# Altium schematic sheet Microcontroller.SchDoc(Microcontroller)
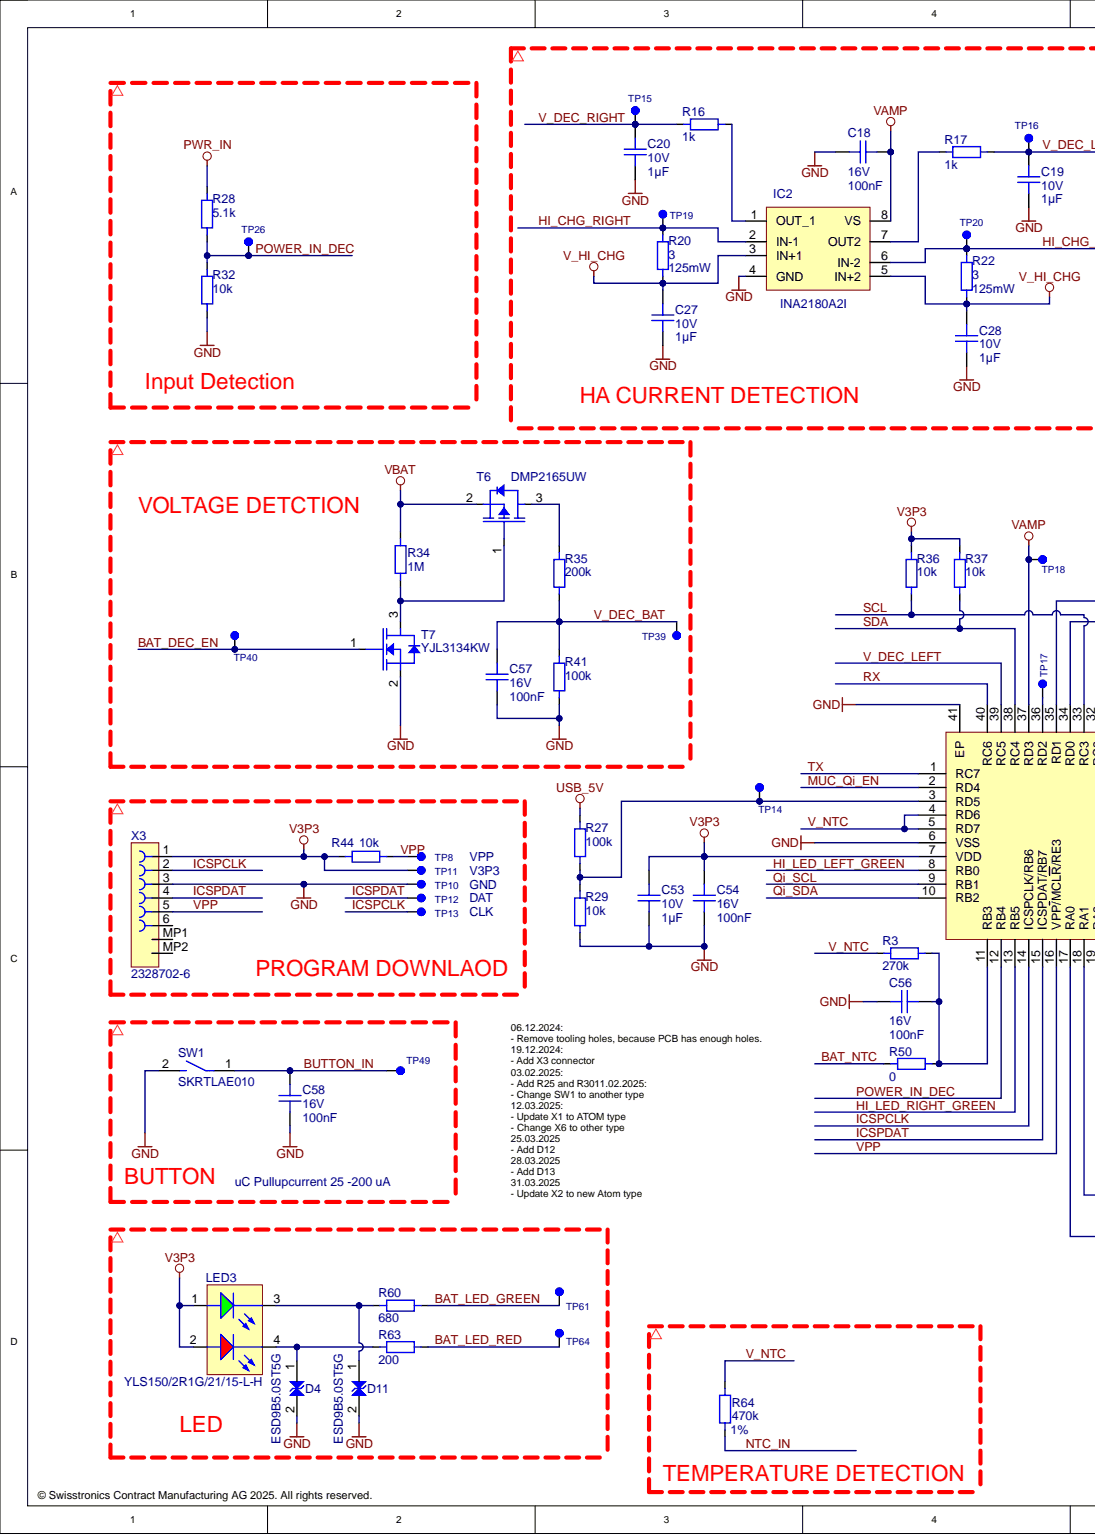
[diagram p0: Microcontroller.SchDoc, left]
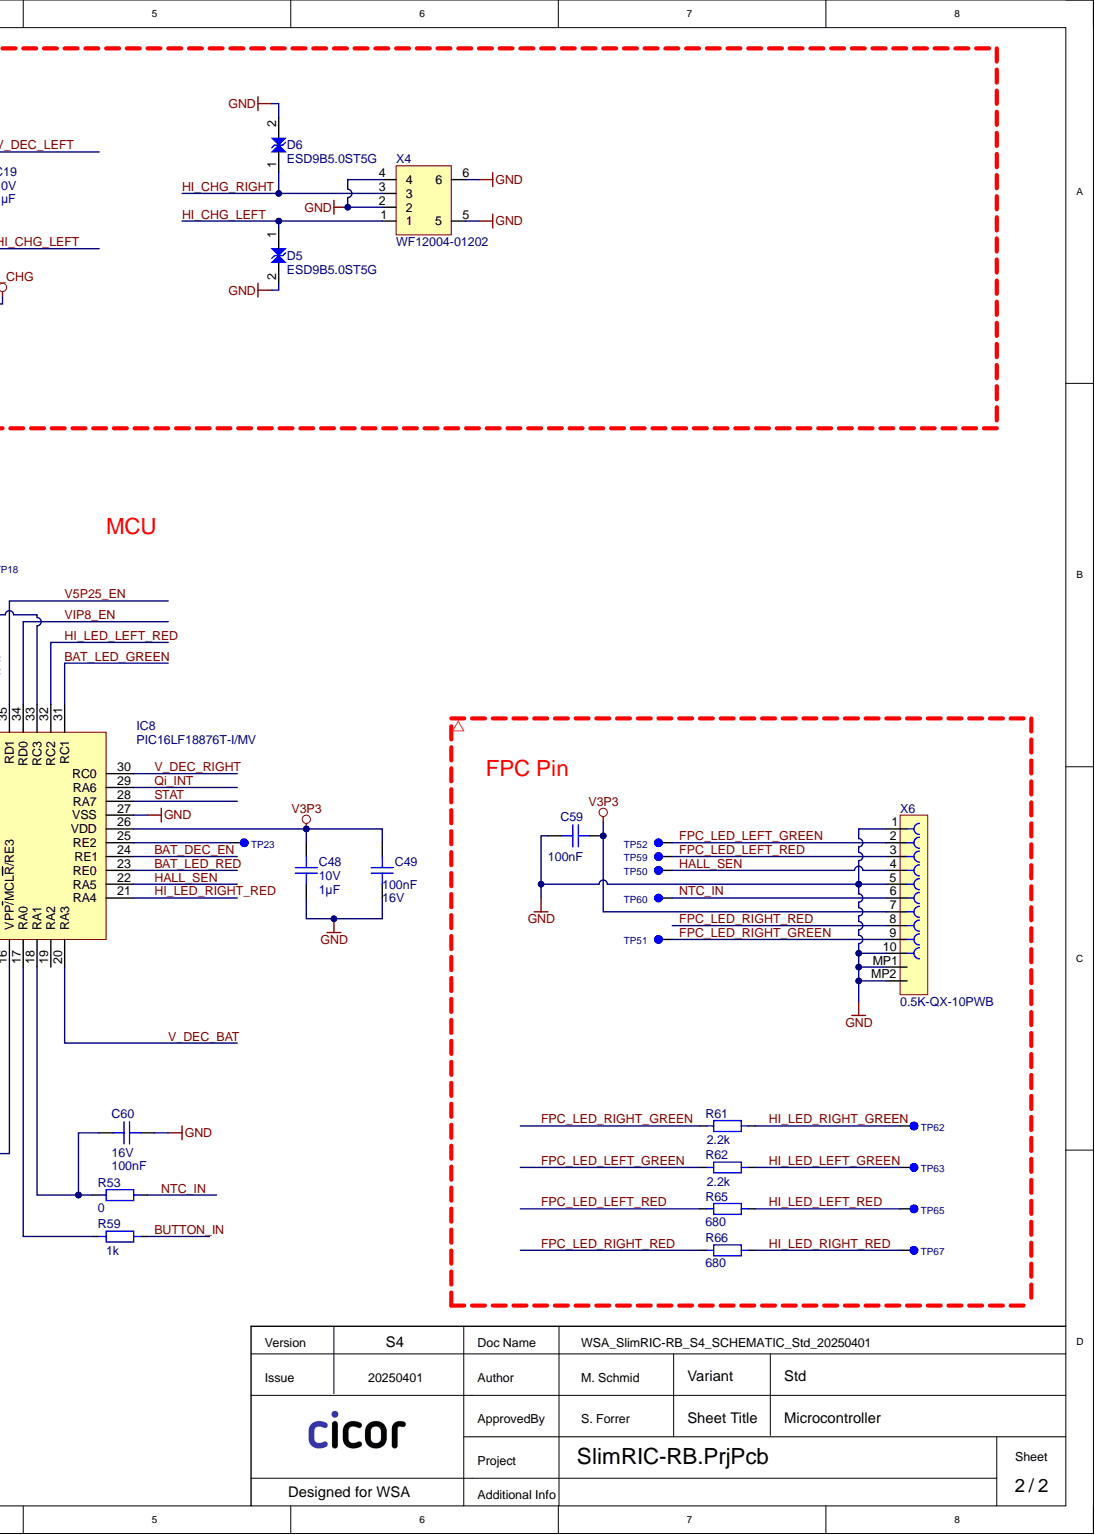
[diagram p1: Microcontroller.SchDoc, right]

## Parts
C18  value 100nF  VoltageRated: 16V  [CAPC0603X02N-0201IPC]  (hidden comment: C_100nF_16V_10%_X5R_0201)
C19  value 1µF  VoltageRated: 10V  [CAPC0603X02N-0201IPC]  (hidden comment: C_1uF_10V_20%_X5R_0201)
C20  value 1µF  VoltageRated: 10V  [CAPC0603X02N-0201IPC]  (hidden comment: C_1uF_10V_20%_X5R_0201)
C27  value 1µF  VoltageRated: 10V  [CAPC0603X02N-0201IPC]  (hidden comment: C_1uF_10V_20%_X5R_0201)
C28  value 1µF  VoltageRated: 10V  [CAPC0603X02N-0201IPC]  (hidden comment: C_1uF_10V_20%_X5R_0201)
C48  value 1µF  VoltageRated: 10V  [CAPC0603X02N-0201IPC]  (hidden comment: C_1uF_10V_20%_X5R_0201)
C49  value 100nF  VoltageRated: 16V  [CAPC0603X02N-0201IPC]  (hidden comment: C_100nF_16V_10%_X5R_0201)
C53  value 1µF  VoltageRated: 10V  [CAPC0603X02N-0201IPC]  (hidden comment: C_1uF_10V_20%_X5R_0201)
C54  value 100nF  VoltageRated: 16V  [CAPC0603X02N-0201IPC]  (hidden comment: C_100nF_16V_10%_X5R_0201)
C56  value 100nF  VoltageRated: 16V  [CAPC0603X02N-0201IPC]  (hidden comment: C_100nF_16V_10%_X5R_0201)
C57  value 100nF  VoltageRated: 16V  [CAPC0603X02N-0201IPC]  (hidden comment: C_100nF_16V_10%_X5R_0201)
C58  value 100nF  VoltageRated: 16V  [CAPC0603X02N-0201IPC]  (hidden comment: C_100nF_16V_10%_X5R_0201)
C59  value 100nF  [CAPC0603X02N-0201IPC]  (hidden comment: C_100nF_16V_10%_X5R_0201)
C60  value 100nF  VoltageRated: 16V  [CAPC0603X02N-0201IPC]  (hidden comment: C_100nF_16V_10%_X5R_0201)
D4  value ESD9B5.0ST5G  [SOD-923]  (hidden comment: Onsemi_ESD9B5.0)
D5  value ESD9B5.0ST5G  Manufacturer3PN: ESD9B5.0ST5G  [SOD-923]  (hidden comment: Onsemi_ESD9B5.0)
D6  value ESD9B5.0ST5G  Manufacturer3PN: ESD9B5.0ST5G  [SOD-923]  (hidden comment: Onsemi_ESD9B5.0)
D11  value ESD9B5.0ST5G  Manufacturer3PN: ESD9B5.0ST5G  [SOD-923]  (hidden comment: Onsemi_ESD9B5.0)
IC2  value INA2180A2I  [VSSOP-8]  (hidden comment: TI_INA2180A2IDGKR)
IC8  value PIC16LF18876T-I/MV  [QFN40P500X500X90_HS-41L]  (hidden comment: Microchip_PIC16LF18876T-I/MV)
LED3  value YLS150/2R1G/21/15-L-H  Manufacturer1PN: YLS150/2R1G/21/15-L-H  [Y.LIN YLS150/2R1G/21/15-L-H]  (hidden comment: YLIN_YLS150/2R1G/21/15-L-H)
R3  value 270k  [RESC0603X02N-0201IPC]  (hidden comment: R_270k_50mW_200ppm_1%_0201)
R16  value 1k  [RESC0603X02N-0201IPC]  (hidden comment: R_1k_50mW_200ppm_1%_0201)
R17  value 1k  [RESC0603X02N-0201IPC]  (hidden comment: R_1k_50mW_200ppm_1%_0201)
R20  value 3  PowerDissipation: 125mW  [RESC2012X06L]  (hidden comment: R_3R_125mW_200ppm_1%_0805)
R22  value 3  PowerDissipation: 125mW  [RESC2012X06L]  (hidden comment: R_3R_125mW_200ppm_1%_0805)
R27  value 100k  [RESC0603X02N-0201IPC]  (hidden comment: R_100k_50mW_200ppm_1%_0201)
R28  value 5.1k  [RESC0603X02N-0201IPC]  (hidden comment: R_5.1k_50mW_200ppm_1%_0201)
R29  value 10k  [RESC0603X02N-0201IPC]  (hidden comment: R_10k_50mW_200ppm_1%_0201)
R32  value 10k  [RESC0603X02N-0201IPC]  (hidden comment: R_10k_50mW_200ppm_1%_0201)
R34  value 1M  [RESC0603X02N-0201IPC]  (hidden comment: R_1M_50mW_200ppm_1%_0201)
R35  value 200k  [RESC0603X02N-0201IPC]  (hidden comment: R_200k_50mW_200ppm_1%_0201)
R36  value 10k  [RESC0603X02N-0201IPC]  (hidden comment: R_10k_50mW_200ppm_1%_0201)
R37  value 10k  [RESC0603X02N-0201IPC]  (hidden comment: R_10k_50mW_200ppm_1%_0201)
R41  value 100k  [RESC0603X02N-0201IPC]  (hidden comment: R_100k_50mW_200ppm_1%_0201)
R44  value 10k  [RESC0603X02N-0201IPC]  (hidden comment: R_10k_50mW_200ppm_1%_0201)
R50  value 0  [RESC0603X02N-0201IPC]  (hidden comment: R_0R_0.5A_0201)
R53  value 0  [RESC0603X02N-0201IPC]  (hidden comment: R_0R_0.5A_0201)
R59  value 1k  [RESC0603X02N-0201IPC]  (hidden comment: R_1k_50mW_200ppm_1%_0201)
R60  value 680  [RESC0603X02N-0201IPC]  (hidden comment: R_680R_50mW_200ppm_1%_0201)
R61  value 2.2k  [RESC0603X02N-0201IPC]  (hidden comment: R_2.2k_50mW_200ppm_1%_0201)
R62  value 2.2k  [RESC0603X02N-0201IPC]  (hidden comment: R_2.2k_50mW_200ppm_1%_0201)
R63  value 200  [RESC0603X02N-0201IPC]  (hidden comment: R_200R_50mW_200ppm_1%_0201)
R64  value 470k  Tolerance: 1%  [RESC0603X02N-0201IPC]  (hidden comment: R_470k_50mW_200ppm_1%_0201)
R65  value 680  [RESC0603X02N-0201IPC]  (hidden comment: R_680R_50mW_200ppm_1%_0201)
R66  value 680  [RESC0603X02N-0201IPC]  (hidden comment: R_680R_50mW_200ppm_1%_0201)
SW1  value SKRTLAE010  Manufacturer1PN: SKRTLAE010  [Alps_SKRKAGE010]  (hidden comment: ALPS_SKRTLAE010)
T6  value DMP2165UW  [SOT65P210X110-3N]  (hidden comment: Diodes_DMP2165UW-7)
T7  value YJL3134KW  [SOT-323-Yangjie]  (hidden comment: Yangjie_YJL3134KW)
TP8  TestPoint  [TP_080mm]  (value not drawn)  (no BOM)
TP10  TestPoint  [TP_080mm]  (value not drawn)  (no BOM)
TP11  TestPoint  [TP_080mm]  (value not drawn)  (no BOM)
TP12  TestPoint  [TP_080mm]  (value not drawn)  (no BOM)
TP13  TestPoint  [TP_080mm]  (value not drawn)  (no BOM)
TP14  TestPoint  [TP_080mm]  (value not drawn)  (no BOM)
TP15  TestPoint  [TP_080mm]  (value not drawn)  (no BOM)
TP16  TestPoint  [TP_080mm]  (value not drawn)  (no BOM)
TP17  TestPoint  [TP_080mm]  (value not drawn)  (no BOM)
TP18  TestPoint  [TP_080mm]  (value not drawn)  (no BOM)
TP19  TestPoint  [TP_080mm]  (value not drawn)  (no BOM)
TP20  TestPoint  [TP_080mm]  (value not drawn)  (no BOM)
TP23  TestPoint  [TP_080mm]  (value not drawn)  (no BOM)
TP26  TestPoint  [TP_080mm]  (value not drawn)  (no BOM)
TP39  TestPoint  [TP_080mm]  (value not drawn)  (no BOM)
TP40  TestPoint  [TP_080mm]  (value not drawn)  (no BOM)
TP49  TestPoint  [TP_080mm]  (value not drawn)  (no BOM)
TP50  TestPoint  [TP_080mm]  (value not drawn)  (no BOM)
TP51  TestPoint  [TP_080mm]  (value not drawn)  (no BOM)
TP52  TestPoint  [TP_080mm]  (value not drawn)  (no BOM)
TP59  TestPoint  [TP_080mm]  (value not drawn)  (no BOM)
TP60  TestPoint  [TP_080mm]  (value not drawn)  (no BOM)
TP61  TestPoint  [TP_080mm]  (value not drawn)  (no BOM)
TP62  TestPoint  [TP_080mm]  (value not drawn)  (no BOM)
TP63  TestPoint  [TP_080mm]  (value not drawn)  (no BOM)
TP64  TestPoint  [TP_080mm]  (value not drawn)  (no BOM)
TP65  TestPoint  [TP_080mm]  (value not drawn)  (no BOM)
TP67  TestPoint  [TP_080mm]  (value not drawn)  (no BOM)
X3  value 2328702-6  Manufacturer1PN: 2328702-6  [TE_2328702-6]  (hidden comment: TE_2328702-6)
X4  value WF12004-01202  Manufacturer1PN: WF12004-01202  [Atom_WF12004-012XX-4Pin]  (hidden comment: Atom_WF12004-01202-4Pin)
X6  value 0.5K-QX-10PWB  Manufacturer1PN: 0.5K-QX-10PWB  [HDGC_0.5K-QX-10PWB]  (hidden comment: HDGC_0.5K-QX-10PWB)

## Nets
GND: C18.1 C19.1 C20.1 C27.1 C28.1 C48.1 C49.1 C53.1 C54.1 C56.1 C57.2 C58.1 C59.2 C60.1 D4.2 D5.2 D6.2 D11.2 IC2.4(GND) IC8.6(VSS) IC8.27(VSS) IC8.41(EP) R29.1 R32.1 R41.1 SW1.2 T7.2 TP10.1 X3.3 X4.2 X4.4 X4.5 X4.6 X6.1 X6.5 X6.10 X6.MP1 X6.MP2
PWR_IN: R28.1
USB_5V: R27.2
V3P3: C48.2 C49.2 C53.2 C54.2 C59.1 IC8.7(VDD) IC8.26(VDD) LED3.1 LED3.2 R36.2 R37.2 R44.1 TP11.1 X3.1 X6.7
V_HI_CHG: C27.2 C28.2 IC2.3(IN+1) IC2.5(IN+2) R20.1 R22.1
VAMP: C18.2 IC2.8(VS) IC8.37(RD3) TP18.1
VBAT: R34.2 T6.2
BAT_DEC_EN: IC8.24(RE1) T7.1 TP40.1
BAT_LED_GREEN: IC8.31(RC1) R60.2 TP61.1
BAT_LED_RED: IC8.23(RE0) R63.2 TP64.1
BAT_NTC: R50.1
BUTTON_IN: C58.2 R59.2 SW1.1 TP49.1
FPC_LED_LEFT_GREEN: R62.1 TP52.1 X6.2
FPC_LED_LEFT_RED: R65.1 TP59.1 X6.3
FPC_LED_RIGHT_GREEN: R61.1 TP51.1 X6.9
FPC_LED_RIGHT_RED: R66.1 X6.8
HALL_SEN: IC8.22(RA5) TP50.1 X6.4
HI_CHG_LEFT: D5.1 IC2.6(IN-2) R22.2 TP20.1 X4.1
HI_CHG_RIGHT: D6.1 IC2.2(IN-1) R20.2 TP19.1 X4.3
HI_LED_LEFT_GREEN: IC8.8(RB0) R62.2 TP63.1
HI_LED_LEFT_RED: IC8.32(RC2) R65.2 TP65.1
HI_LED_RIGHT_GREEN: IC8.13(RB5) R61.2 TP62.1
HI_LED_RIGHT_RED: IC8.21(RA4) R66.2 TP67.1
ICSPCLK: IC8.14(ICSPCLK/RB6) TP13.1 X3.2
ICSPDAT: IC8.15(ICSPDAT/RB7) TP12.1 X3.4
MUC_Qi_EN: IC8.2(RD4)
NTC_IN: R53.2 R64.1 TP60.1 X6.6
POWER_IN_DEC: IC8.12(RB4) R28.2 R32.2 TP26.1
Qi_INT: IC8.29(RA6)
Qi_SCL: IC8.9(RB1)
Qi_SDA: IC8.10(RB2)
RX: IC8.40(RC6)
SCL: IC8.33(RC3) R36.1
SDA: IC8.38(RC4) R37.1
STAT: IC8.28(RA7)
TX: IC8.1(RC7)
V5P25_EN: IC8.35(RD1)
V_DEC_BAT: C57.1 IC8.20(RA3) R35.1 R41.2 TP39.1
V_DEC_LEFT: C19.2 IC8.39(RC5) R17.1 TP16.1
V_DEC_RIGHT: C20.2 IC8.30(RC0) R16.1 TP15.1
V_NTC: IC8.4(RD6) IC8.5(RD7) R3.1 R64.2
VIP8_EN: IC8.34(RD0)
VPP: IC8.16(VPP/MCLR/RE3) R44.2 TP8.1 X3.5
NetC56_2: C56.2 IC8.11(RB3) R3.2 R50.2
NetC60_2: C60.2 IC8.18(RA1) R53.1
NetD4_1: D4.1 LED3.4 R63.1
NetD11_1: D11.1 LED3.3 R60.1
NetIC2_1: IC2.1(OUT_1) R16.2
NetIC2_7: IC2.7(OUT2) R17.2
NetIC8_3: IC8.3(RD5) R27.1 R29.2 TP14.1
NetIC8_17: IC8.17(RA0) R59.1
NetIC8_25: IC8.25(RE2) TP23.1
NetIC8_36: IC8.36(RD2) TP17.1
NetR34_1: R34.1 T6.1 T7.3
NetR35_2: R35.2 T6.3

Not connected: IC8 19(RA2); X3 6 MP1 MP2

## Library data of the parts
C18 C49 C54 C56-C60 = CMP-263-000020-11: CAP.CER 100nF 16V X5R 0201; Manufacturer1: Yageo; Manufacturer1PN: CC0201KRX5R7BB104; PartNumber: 4079999; PartNumberCicor: 100nF/16V/X5R/0603(0201)/10%; TempRange: -40°C/+85°C; Tolerance: 10%; Type: Ceramic; Component Kind: Standard; Dielectric: X5R; Housing: 0201; Manufacturer2: Various; Manufacturer2PN: C_100nF_16V_10%_X5R_0201; PartNumberR&D: C_100nF_16V_10%_X5R_0201; Library Name: SWT
  C56 C60: Value: 100nF
  C59: VoltageRated: 16V
C19 C20 C27 C28 C48 C53 = CMP-263-000197-11: CAP.CER 1uF 10V 20% X5R 0201; Manufacturer1: Samsung; Manufacturer1PN: CL03A105MP3ZSNH; Manufacturer2PN: CM03X5R105M10AH; TempRange: -55°C/+85°C; Type: Ceramic; Component Kind: Standard; Dielectric: X5R; Housing: 0201; Manufacturer2: Kyocera; Manufacturer3: Various; Manufacturer3PN: C_1uF_10V_20%_X5R_0201; Supplier1: Digi-Key; Supplier1PN: 1253-CM03X5R105M10AHCT-ND; Tolerance: 20%; Library Name: SWT
D4 = CMP-00108-13: TVS DIODE 5VWM SOD923; Manufacturer1: LRC; Manufacturer1PN: LESD9D5.0CT5G; Manufacturer2PN: ESD9D5C; Manufacturer3PN: ESD9B5.0ST5G; PartNumber: onsemi_ESD9B5.0ST5G; TempRange: -55°C/+150°C; Value: ESD9B5.0ST5G; VoltageBreakdown: 5.8V; VoltageRated: 5V; Availability: SiliconExpert 02.02.2023: 6.6 years; ClassName: LED; Component Kind: Standard; ComponentLink1Description: Datasheet; ComponentLink1URL: \\CTCHFS01.cicor.local\epg$\EDA\Documents\Datasheets\ESD9B-D.PDF; ComponentLink2Description: Datasheet Web; ComponentLink2URL: https://www.onsemi.cn/pdf/datasheet/esd9b-d.pdf; ComponentLink3Description: Datasheet Leiditech; ComponentLink3URL: \\CTCHFS01.cicor.local\epg$\EDA\Documents\Datasheets\ESD9D5C.pdf; ComponentLink4Description: Datasheet Leiditech Web; ComponentLink4URL: https://de.leiditech.com/u_file/file/products/2212/16/86a2a579d2.pdf; ComponentLink5Description: Datasheet LRC; ComponentLink5URL: \\CTCHFS01.cicor.local\epg$\EDA\Documents\Datasheets\LRC_LESD9D5.0CT5G.pdf; Housing: SOD923; Manufacturer2: Leiditech; Manufacturer3: Onsemi; PartNumberCicor: onsemi_ESD9B5.0ST5G; Library Name: SWT
D5 D6 D11 = CMP-00108-13: TVS DIODE 5VWM SOD923; Manufacturer1: LRC; Manufacturer1PN: LESD9D5.0CT5G; Manufacturer2PN: ESD9D5C; PartNumber: onsemi_ESD9B5.0ST5G; TempRange: -55°C/+150°C; VoltageBreakdown: 5.8V; VoltageRated: 5V; Availability: SiliconExpert 02.02.2023: 6.6 years; Component Kind: Standard; ComponentLink1Description: Datasheet; ComponentLink1URL: \\CTCHFS01.cicor.local\epg$\EDA\Documents\Datasheets\ESD9B-D.PDF; ComponentLink2Description: Datasheet Web; ComponentLink2URL: https://www.onsemi.cn/pdf/datasheet/esd9b-d.pdf; ComponentLink3Description: Datasheet Leiditech; ComponentLink3URL: \\CTCHFS01.cicor.local\epg$\EDA\Documents\Datasheets\ESD9D5C.pdf; ComponentLink4Description: Datasheet Leiditech Web; ComponentLink4URL: https://de.leiditech.com/u_file/file/products/2212/16/86a2a579d2.pdf; ComponentLink5Description: Datasheet LRC; ComponentLink5URL: \\CTCHFS01.cicor.local\epg$\EDA\Documents\Datasheets\LRC_LESD9D5.0CT5G.pdf; Housing: SOD923; Manufacturer2: Leiditech; Manufacturer3: Onsemi; PartNumberCicor: onsemi_ESD9B5.0ST5G; Library Name: SWT
  D11: ClassName: LED
IC2 = CMP-00101-9: INA2180A2; Manufacturer1: Texas Instruments; PartDescription: Current Sense Amplifiers 26V, dual channel, 350kHz current sense amplifier VSSOP-8; CurrentSupply: 500 uA; TempRange: -40°C /125°C; VoltageRated: 2.7 - 5.5V; Availability: SiliconExpert 18.01.2023: 10 Years; Component Kind: Standard; ComponentLink1Description: Datasheet; ComponentLink1URL: \\CTCHFS01.cicor.local\epg$\EDA\Documents\Datasheets\ina4180.pdf; ComponentLink2Description: DatasheetWeb; ComponentLink2URL: https://www.ti.com/lit/ds/symlink/ina4180.pdf?HQS=dis-mous-null-mousermode-dsf-pf-null-wwe&ts=1674025425967&ref_url=https%253A%252F%252Fwww.mouser.pl%252F; Manufacturer1PN: INA2180A2IDGKR; PartNumber: TI_INA2180A2IDGKR; PartNumberCicor: TI_INA2180A2IDGKR; PartNumberR&D: TI_INA2180A2IDGKR; Status: checked&used; Type: OpAmp Current Sense; Library Name: SWT; Modified: 18.01.2023 JAA; 02.02.2023 FS
IC8 = CMP-00103-7: PIC16LF18876T-I/MV; Manufacturer1: Microchip Technology; PartDescription: IC MCU 8BIT 28KB FLASH 40UQFN; Core: PIC; TempRange: -40°C ~ 85°C; VoltageRated: 1.8V-3.6V; Availability: Silicon Expert 02.02.2023: 6 Years; ClassName: MCU; Component Kind: Standard; ComponentLink1Description: Datasheet; ComponentLink1URL: \\CTCHFS01.cicor.local\epg$\EDA\Documents\Datasheets\PIC16(L)F18856-76-Data-Sheet-40001824F.pdf; ComponentLink2Description: DatasheetWeb; ComponentLink2URL: https://www.mouser.ch/datasheet/2/268/PIC16_L_F18856_76_Data_Sheet_40001824F-2005138.pdf; Manufacturer1PN: PIC16LF18876T-I/MV; PartNumber: Microchip_PIC16LF18876T-I/MV; PartNumberCicor: Microchip_PIC16LF18876T-I/MV; PartNumberR&D: Microchip_PIC16LF18876T-I/MV; Status: checked&used; Type: Microcontrollers; Library Name: SWT; Modified: 18.01.2023 JAA 26.01.2023 KABE; 02.02.2023 FS
LED3 = CMP-00481-5: LED DUO red / green; Manufacturer1: Y.LIN; Brightness: Red: 160-350 mcd / Green: 600-1300 mcd; CurrentRating: 25mA; ForwardVoltage: R: 1.8-2.4V, G: 2.8-3.4V; PowerDissipation: R: 60mW, G: 85mW; ClassName: LED; Color: red/green; Component Kind: Standard; ComponentLink1Description: Datasheet; ComponentLink1URL: \\CTCHFS01.cicor.local\epg$\EDA\Documents\Datasheets\Y.LIN_YLS 1502R1G2115.pdf; Library Name: SWT
R3 = CMP-00218-4: RES 270k 50mW 200ppm 1% 0201; Manufacturer1: YAGEO CORPORATION; Manufacturer1PN: RC0201FR-07270KL; Manufacturer2PN: CRCW0201270KFNED; Manufacturer3PN: RK73H1HTTC2703F; Manufacturer4PN: ERJ-1GNF2703C; PartNumberCicor: 4080039; Component Kind: Standard; Housing: 0201; Manufacturer2: VISHAY INTERTECHNOLOGY,INC; Manufacturer3: KOA EUROPE GMBH; Manufacturer4: PANASONIC (MATSUSHITA); Manufacturer5: various; Manufacturer5PN: R_270k_50mW_200ppm_1%_0201; PartDescription: RES 270k 50mW 200ppm 1% 0201; PartNumber: R_270k_50mW_200ppm_1%_0201; PartNumberR&D: R_270k_50mW_200ppm_1%_0201; PowerDissipation: 50mW; PPM: 200ppm; Status: new & checked; Tolerance: 1%; Library Name: SWT; Modified: 23.05.2023 SM / review MN
R16 R17 R59 = CMP-232-000484-8: 1 k; Manufacturer1: Yageo; Manufacturer1PN: RC0201FR-071KL; PowerDissipation: 50mW; PPM: 200 ppm; Tolerance: 1%; Component Kind: Standard; Housing: 0201; Manufacturer2: various; Manufacturer2PN: R_1k_50mW_200ppm_1%_0201; PartNumberR&D: R_1k_50mW_200ppm_1%_0201; Library Name: SWT
R20 R22 = CMP-00263-5: RES 3R 1% 0805; Manufacturer1: YAGEO; Manufacturer1PN: SR0805FR-073RL; Manufacturer2PN: RCS08053R00FKEA; Manufacturer3PN: SG73P2ATTD3R00F; Manufacturer4PN: RPC0805FT3R00-UP; PPM: 200 ppm; TempRange: -55°C/+155°C; Component Kind: Standard; Housing: 0805; Manufacturer2: Vishay Dale; Manufacturer3: KOA Speer Electronics, Inc.; Manufacturer4: Stackpole Electronics Inc; PartNumber: R_3R_125mW_200ppm_1%_0805; PartNumberCicor: R_3R_125mW_200ppm_1%_0805; Tolerance: 1%; Library Name: SWT
R27 R41 = CMP-232-000486-8: RES 100k 50mW 200ppm 1% 0201; Manufacturer1: Bourns; Manufacturer1PN: CR0201-FW-1003GLF; Component Kind: Standard; Housing: 0201; Manufacturer2: various; Manufacturer2PN: R_100k_50mW_200ppm_1%_0201; PartNumberCicor: R_100k_50mW_200ppm_1%_0201; PartNumberR&D: R_100k_50mW_200ppm_1%_0201; PowerDissipation: 50mW; PPM: 200 ppm; Tolerance: 1%; Library Name: SWT
  R41: ClassName: VOLTAGE DETECTION
R28 = CMP-00121-8: RES 5.1k 50mW 200ppm 1% 0201; Manufacturer1: Panasonic Electronic Components; Manufacturer1PN: ERJ-1GNF5101C; Component Kind: Standard; Housing: 0201; Manufacturer2: Various; Manufacturer2PN: R_5.1k_50mW_200ppm_1%_0201; PartDescription: RES 5.1k 50mW 200ppm 1% 0201; PartNumber: R_5.1k_50mW_200ppm_1%_0201; PartNumberCicor: R_5.1k_50mW_200ppm_1%_0201; PartNumberR&D: R_5.1k_50mW_200ppm_1%_0201; PowerDissipation: 50mW; PPM: 200ppm; Status: new&checked; Tolerance: 1%; Library Name: SWT; Modified: 20.01.2023 JAA; 02.02.2023 FS
R29 R32 R36 R37 R44 = CMP-232-000108-9: RES 10k 50mW 200ppm 1% 0201; Manufacturer1: Stackpole Electronics; Manufacturer1PN: RMCF0201FT10K0; PartNumber: 4061069; Component Kind: Standard; Housing: 0201; Manufacturer2: various; Manufacturer2PN: R_10k_50mW_200ppm_1%_0201; PartNumberCicor: 4061069; PartNumberR&D: R_10k_50mW_200ppm_1%_0201; PowerDissipation: 50mW; PPM: 200 ppm; Tolerance: 1%; Library Name: SWT
R34 = CMP-232-000473-6: RES 1M 50mW 200ppm 1% 0201; Manufacturer1: KOA Speer; Manufacturer1PN: RK73H1HTTC1004F; Component Kind: Standard; Housing: 0201; Manufacturer2: various; Manufacturer2PN: R_1M_50mW_200ppm_1%_0201; PowerDissipation: 50mW; PPM: 200 ppm; Tolerance: 1%; Library Name: SWT
R35 = CMP-00123-7: RES 200k 50mW 200ppm 1% 0201; Manufacturer1: Yageo; Manufacturer1PN: RC0201FR-07200KP; Component Kind: Standard; Housing: 0201; Manufacturer2: various; Manufacturer2PN: R_200k_50mW_200ppm_1%_0201; PartNumber: R_200k_50mW_200ppm_1%_0201; PartNumberCicor: R_200k_50mW_200ppm_1%_0201; PowerDissipation: 50mW; PPM: 200 ppm; Tolerance: 1%; Library Name: SWT
R50 R53 = CMP-232-000030-8: RES 0R 0201; Manufacturer1: PANASONIC (MATSUSHITA); Manufacturer1PN: ERJ-1GN0R00C; PartNumber: 4080046; Tolerance: 0%; Component Kind: Standard; Housing: 0201; Manufacturer2: various; Manufacturer2PN: R_0R_0.5A_0201; PartNumberCicor: 4080046; PartNumberR&D: R_0R_0.5A_0201; Library Name: SWT
R60 R65 R66 = CMP-00252-5: RES 680R 50mW 200ppm 1% 0201; Manufacturer1: Panasonic Electronic Components; Manufacturer1PN: ERJ-1GNF6800C; Component Kind: Standard; Housing: 0201; Manufacturer2: Various; Manufacturer2PN: R_680R_50mW_200ppm_1%_0201; PartDescription: RES 680R 50mW 200ppm 1% 0201; PartNumber: R_680R_50mW_200ppm_1%_0201; PartNumberCicor: R_680R_50mW_200ppm_1%_0201; PartNumberR&D: R_680R_50mW_200ppm_1%_0201; PowerDissipation: 50mW; PPM: 200 ppm; Status: new & checked; Tolerance: 1%; Library Name: SWT; Modified: 25.07.2023 SM Review MN
R61 R62 = CMP-00135-7: RES 2.2k 50mW 200ppm 1% 0201; Manufacturer1: Vishay; Manufacturer1PN: CRCW02012K20FKED; PartNumberCicor: 4060817; Component Kind: Standard; Housing: 0201; Manufacturer2: various; Manufacturer2PN: R_2.2k_50mW_200ppm_1%_0201; PartNumber: R_2.2k_50mW_200ppm_1%_0201; PowerDissipation: 50mW; PPM: 200ppm; Tolerance: 1%; Library Name: SWT
R63 = CMP-232-000489-6: RES 200R 50mW 200ppm 1% 0201; Manufacturer1: Panasonic Electronic Components; Manufacturer1PN: ERJ-1GNF2000C; Component Kind: Standard; Housing: 0201; Manufacturer2: various; Manufacturer2PN: R_200R_50mW_200ppm_1%_0201; PartDescription: RES 200R 50mW 200ppm 1% 0201; PartNumber: R_200R_50mW_200ppm_1%_0201; PartNumberCicor: R_200R_50mW_200ppm_1%_0201; PartNumberR&D: R_200R_50mW_200ppm_1%_0201; PowerDissipation: 50mW; PPM: 200ppm; Status: used; Tolerance: 1%; Library Name: SWT; Modified: 21.07.2021 SM; 02.02.2023 FS
R64 = CMP-00124-6: RES 470k 50mW 200ppm 1% 0201; Manufacturer1: KOA Speer; Manufacturer1PN: RK73H1HTTC4703F; Component Kind: Standard; Housing: 0201; Manufacturer2: various; Manufacturer2PN: R_470k_50mW_200ppm_1%_0201; PartNumber: R_470k_50mW_200ppm_1%_0201; PartNumberCicor: R_470k_50mW_200ppm_1%_0201; PowerDissipation: 50mW; PPM: 200 ppm; Library Name: SWT
SW1 = CMP-00674-3: Tactile Push button; Manufacturer1: Alps-Alpine; Manufacturer2PN: Y97PS18B2ABFP; Manufacturer3PN: KAN4548-0343B0201; PartNumberCicor: 4078041; CurrentRating: 50mA; VoltageRated: 12V; Component Kind: Standard; ComponentLink1Description: Datasheet Alps; ComponentLink1URL: \\CTCHFS01.cicor.local\epg$\EDA\Documents\Datasheets\SKRT-1370725.pdf; ComponentLink2Description: Datasheet C&K; ComponentLink2URL: \\CTCHFS01.cicor.local\epg$\EDA\Documents\Datasheets\PTS845Vx20PSMTR2LFS_Dwg_RevA_16-07-15.pdf; ComponentLink3Description: Datasheet C&K Web; ComponentLink3URL: https://media.digikey.com/pdf/Data%20Sheets/C&K/PTS845Vx20PSMTR2LFS_Dwg_RevA_16-07-15.pdf; ComponentLink4Description: Datasheet Alps Web; ComponentLink4URL: https://www.mouser.ch/datasheet/2/15/SKRT-1370725.pdf; ComponentLink5Description: Datasheet Gangyuan; ComponentLink5URL: \\CTCHFS01.cicor.local\epg$\EDA\Documents\Datasheets\KAN4548-0353B0201.pdf; Manufacturer2: C&K; Manufacturer3: WENZHOU GANGYUAN ELECTRONICS CO.,LTD.; PartNumberR&D: ALPS_SKRTLAE010; Type: Button; Library Name: SWT
T6 = CMP-00111-6: PMF170XP,115; Manufacturer1: Diodes; Manufacturer2PN: PJC7407_R1_00001; Manufacturer4PN: YJL2101W; PartDescription: TRN.MOSFET P FDN304P; CurrentRating: -1A; RDS_ON: 0.2; RDS_ON_@: 200mR@-4.5V/1A; TempRange: -55°C/+150°C; Type: P; VoltageRated: -20V; Availability: SiliconExpert 19.01.2023: 6.4 years - 8 years; Component Kind: Standard; ComponentLink1Description: Datasheet Nexperia; ComponentLink1URL: \\CTCHFS01.cicor.local\epg$\EDA\Documents\Datasheets\PMF170XP.pdf; ComponentLink2Description: Datasheet Nexperia Web; ComponentLink2URL: https://assets.nexperia.com/documents/data-sheet/PMF170XP.pdf; ComponentLink3Description: Datasheet Panjit; ComponentLink3URL: \\CTCHFS01.cicor.local\epg$\EDA\Documents\Datasheets\PJC7407.pdf; ComponentLink4Description: Datasheet Panjit Web; ComponentLink4URL: https://www.panjit.com.tw/upload/datasheet/PJC7407.pdf; ComponentLink5Description: Datasheet Diodes; ComponentLink5URL: \\CTCHFS01.cicor.local\epg$\EDA\Documents\Datasheets\DMP2165UW.pdf; ComponentLink6Description: Datasheet Diodes Web; ComponentLink6URL: https://www.diodes.com/assets/Datasheets/DMP2165UW.pdf; ComponentLink7Description: Datasheet Yangjie; ComponentLink7URL: \\CTCHFS01.cicor.local\epg$\EDA\Documents\Datasheets\Yangjie YJL2101W.pdf; ComponentLink8Description: Datasheet Yangjie Web; ComponentLink8URL: https://www.21yangjie.com/ggs/mosfet/zdymosfet/YJL2101W.pdf; Housing: SOT65P210X110; Manufacturer1PN: DMP2165UW-7; Manufacturer2: Panjit International Inc.; Manufacturer3: Nexperia USA Inc.; Manufacturer3PN: PMF170XP,115; Manufacturer4: Yangzhou Yangjie Electronic Technology Co., Ltd.; Library Name: SWT; Modified: 19.01.2023 SM; 02.02.2023 FS; add source 10.02.2023 SM
T7 = CMP-00119-5: YJL3134KW; Manufacturer1: Yangzhou Yangjie Electronic Technology Co.,Ltd; Manufacturer2PN: PJC7406_R1_00001; Manufacturer3PN: SI3134KWA-TP; Manufacturer4PN: YJL3134KBW; PartDescription: TRN.MOSFET N YJL3134KW 20V 0.50A; CurrentRating: 0.50 A; RDS_ON: 300mR@4.5V/0.5A; TempRange: -55°C/+150°C; Type: N; VoltageRated: 20 V; Availability: SiliconExpert 20.01.2023: 7.5 years; ClassName: VOLTAGE DETECTION; Component Kind: Standard; ComponentLink1Description: Datasheet Yangjie; ComponentLink1URL: \\CTCHFS01.cicor.local\epg$\EDA\Documents\Datasheets\YJL3134KW.pdf; ComponentLink2Description: Datasheet Yangjie Web; ComponentLink2URL: https://www.21yangjie.com/style/pdf/low-voltage-mosfet/YJL3134KW.pdf; ComponentLink3Description: Datasheet Panjit; ComponentLink3URL: \\CTCHFS01.cicor.local\epg$\EDA\Documents\Datasheets\PJC7406.pdf; ComponentLink4Description: Datasheet Panjit Web; ComponentLink4URL: https://www.panjit.com.tw/upload/datasheet/PJC7406.pdf; ComponentLink5Description: Datasheet Micro; ComponentLink5URL: \\CTCHFS01.cicor.local\epg$\EDA\Documents\Datasheets\SI3134KWA(SOT-323).pdf; ComponentLink6Description: Datasheet Micro Web; ComponentLink6URL: https://www.mccsemi.com/pdf/Products/SI3134KWA(SOT-323).pdf; ComponentLink7Description: Datasheet Yangjie; ComponentLink7URL: \\CTCHFS01.cicor.local\epg$\EDA\Documents\Datasheets\SI3134KWA(SOT-323).pdf; ComponentLink8Description: Datasheet Yangjie Web; ComponentLink8URL: https://www.21yangjie.com/ggs/mosfet/zdymosfet/YJL3134KBW.pdf; Manufacturer1PN: YJL3134KW; Manufacturer2: Panjit International Inc.; Manufacturer3: Micro Commercial Co; Manufacturer4: Yangzhou Yangjie Electronic Technology Co., Ltd.; PartNumberR&D: Yangjie_YJL3134KW; Status: new&checked; Library Name: SWT; Modified: 20.01.2023 SM; 08.02.2023 KABE; add source 10.02.2023 SM
TP8 TP10-TP20 TP23 TP26 TP39 TP40 TP49-TP52 TP59-TP65 TP67 = CMP-370-000002-9: TP; PartNumber: TP_mini; Manufacturer1: -; Manufacturer1PN: -; PartNumberCicor: TP_mini; PartNumberR&D: TP_mini; Value: TP; Library Name: SWT
X3 = CMP-00479-3: CONN FPC BOTH 6POS 0.50MM R/A; Manufacturer1: TE Connectivity; Contacts: 6; Current: 500mA; TempRange: -40°C/+85°C; VoltageRated: 50V; Availability: SiliconExpert 07.08.2024: 10.8 Years; Component Kind: Standard; ComponentLink1Description: Datasheet; ComponentLink1URL: \\CTCHFS01.cicor.local\epg$\EDA\Documents\Datasheets\ENG_CD_2328702_6-2033526.pdf; ComponentLink2Description: DatasheetWeb; ComponentLink2URL: https://www.mouser.ch/datasheet/2/418/8/ENG_CD_2328702_6-2033526.pdf; Supplier1: Mouser; Supplier1PN: 571-2328702-6; Library Name: SWT
X4 = CMP-00185-5: CONN HEADER SMD R/A 4POS 1.2mm; Manufacturer1: Shenzhen Atom Technoloty CO. , LTD.; Contacts: 4; Current: 1.0 A AWG30, 1.5 A AWG28; Einbaulage: 180; TempRange: -25°C/+85°C; VoltageRated: 50 V; Availability: ???; ClassName: HA CURRENT DETECTION; Component Kind: Standard; ComponentLink1Description: Datasheet; ComponentLink1URL: \\CTCHFS01.cicor.local\epg$\EDA\Documents\Datasheets\Wafer1.2mm XP 卧式 H=1.4无卤.pdf; PartDescription: CONN HEADER SMD R/A 4POS 1.2mm; PartNumber: Atom_WF12004-01202; PartNumberCicor: Atom_WF12004-01202; PartNumberR&D: Atom_WF12004-01202; Status: new&checked; Library Name: SWT; Modified: 23.03.2023 KABE; checked 29.03.2023 SM
X6 = CMP-00700-5: FFC/FPC  P0.5 10POL 180°; Manufacturer1: HDGC; Manufacturer2PN: AFC05-S10FIA-00; Contacts: 10; Current: 0.4A; TempRange: -55 - 85°C; VoltageRated: 50V; Availability: SiliconExpert 12.03.2024: Unknown; Component Kind: Standard; ComponentLink1Description: Datasheet; ComponentLink1URL: \\CTCHFS01.cicor.local\epg$\EDA\Documents\Datasheets\2410121328_HDGC-0-5K-QX-10PWB_C2919478.pdf; ComponentLink2Description: Datasheet Web; ComponentLink2URL: https://www.lcsc.com/datasheet/lcsc_datasheet_2410121328_HDGC-0-5K-QX-10PWB_C2919478.pdf; ComponentLink3Description: Datasheet E-tec; ComponentLink3URL: \\CTCHFS01.cicor.local\epg$\EDA\Documents\Datasheets\2411220432_JUSHUO-AFC05-S10FIA-00_C561386.pdf; ComponentLink4Description: Datasheet JUSHUO Web; ComponentLink4URL: https://www.lcsc.com/datasheet/lcsc_datasheet_2411220432_JUSHUO-AFC05-S10FIA-00_C561386.pdf; Manufacturer2: JUSHUO; PartNumberR&D: HDGC_0.5K-QX-10PWB; Library Name: SWT
